# Altium schematic sheet 08 CAN .SchDoc
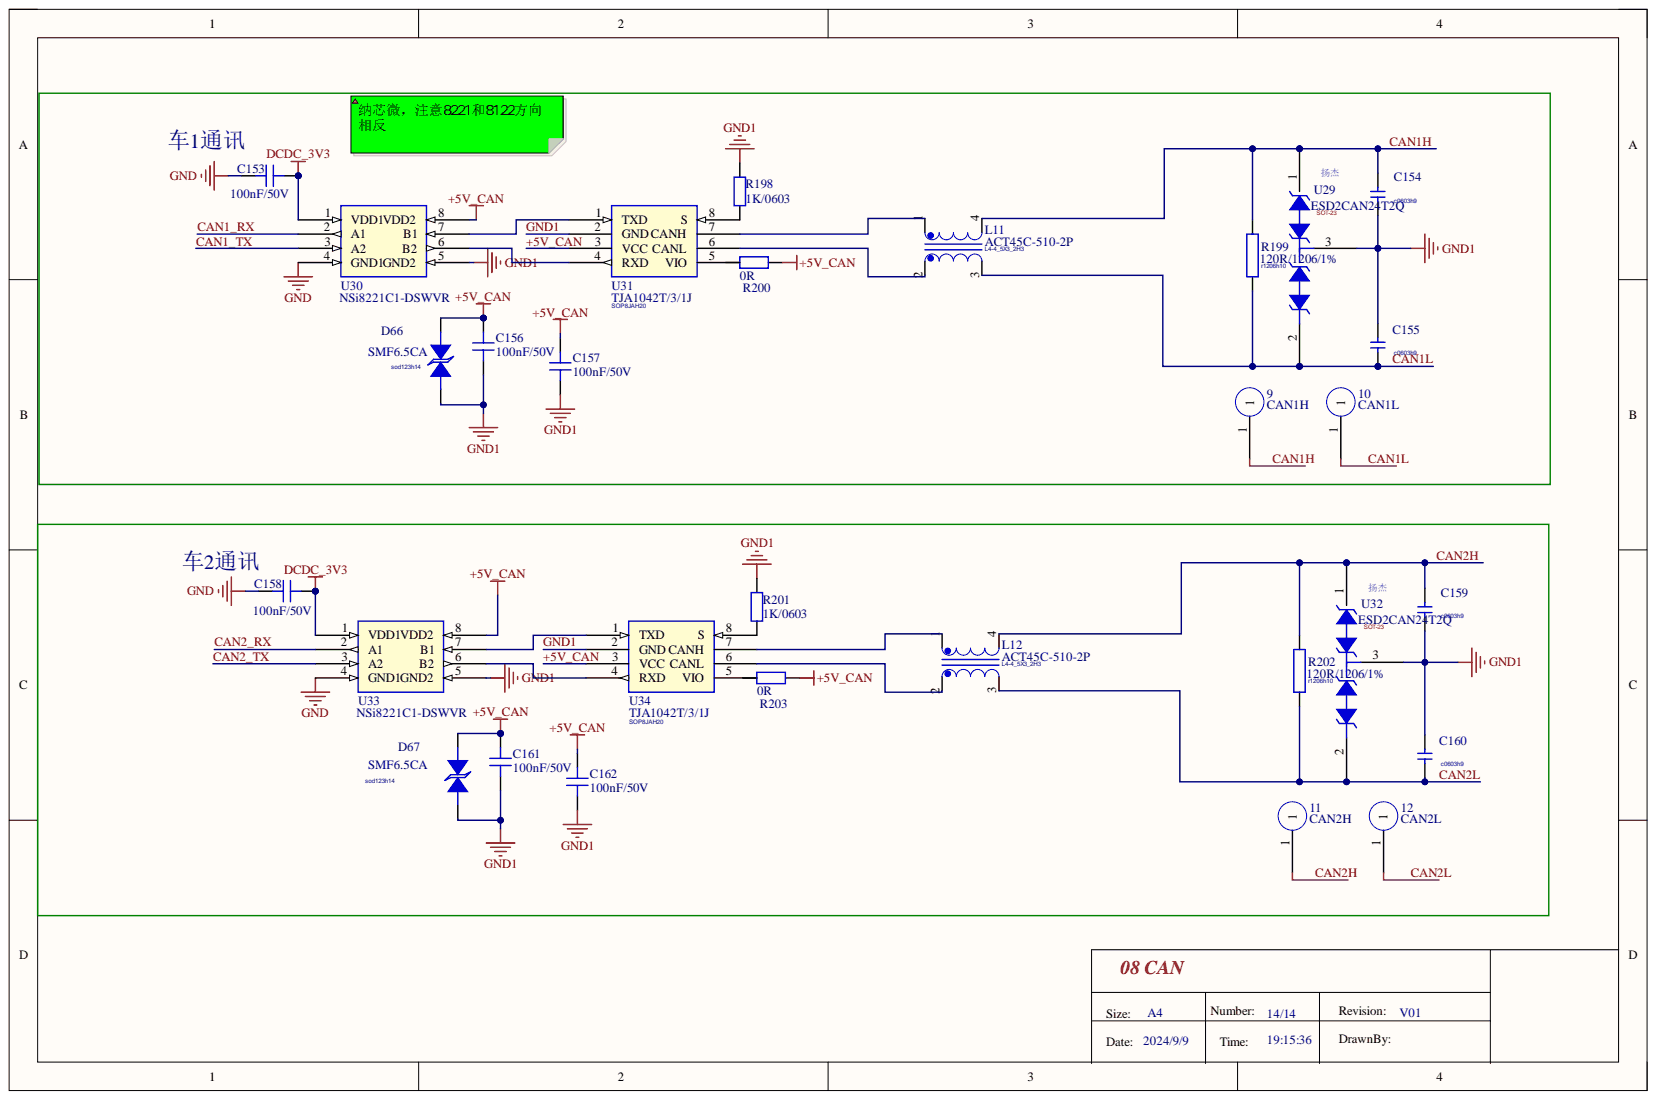
[diagram p0: 08 CAN .SchDoc]

## Parts
9  CAN1H  [TP12]
10  CAN1L  [TP12]
11  CAN2H  [TP12]
12  CAN2L  [TP12]
C153  100nF/50V  [C0603]
C154  PCB Footprint: c0603h9  [C0603]
C155  PCB Footprint: c0603h9  [C0603]
C156  100nF/50V  [C0603]
C157  100nF/50V  [C0603]
C158  100nF/50V  [C0603]
C159  PCB Footprint: c0603h9  [C0603]
C160  PCB Footprint: c0603h9  [C0603]
C161  100nF/50V  [C0603]
C162  100nF/50V  [C0603]
D66  SMF6.5CA  [sod123h14]
D67  SMF6.5CA  [sod123h14]
L11  ACT45C-510-2P  [L4-4_5X3_2H3]
L12  ACT45C-510-2P  [L4-4_5X3_2H3]
R198  1K/0603  [R1206]
R199  120R/1206/1%  [r1206h10]
R200  0R  [R1206]
R201  1K/0603  [R1206]
R202  120R/1206/1%  [r1206h10]
R203  0R  [R1206]
U29  ESD2CAN24T2Q  [SOT-23]
U30  NSi8221C1-DSWVR  [SOIC-8_300mil]
U31  TJA1042T/3/1J  [SOIC-8_150mil]
U32  ESD2CAN24T2Q  [SOT-23]
U33  NSi8221C1-DSWVR  [SOIC-8_300mil]
U34  TJA1042T/3/1J  [SOIC-8_150mil]

## Nets
DCDC_3V3: C153.2 C158.2 U30.1(VDD1) U33.1(VDD1)
GND: C153.1 C158.1 U30.4(GND1) U33.4(GND1)
+5V_CAN: C156.1 C157.1 C161.1 C162.1 D66.2 D67.2 R200.1 R203.1 U30.8(VDD2) U31.3(VCC) U33.8(VDD2) U34.3(VCC)
CAN1_RX: U30.2(A1)
CAN1_TX: U30.3(A2)
CAN1H: 9.1 C154.2 L11.4 R199.1 U29.1
CAN1L: 10.1 C155.1 L11.3 R199.2 U29.2
CAN2_RX: U33.2(A1)
CAN2_TX: U33.3(A2)
CAN2H: 11.1 C159.2 L12.4 R202.1 U32.1
CAN2L: 12.1 C160.1 L12.3 R202.2 U32.2
GND1: C154.1 C155.2 C156.2 C157.2 C159.1 C160.2 C161.2 C162.2 D66.1 D67.1 R198.2 R201.2 U29.3 U30.5(GND2) U31.2(GND) U32.3 U33.5(GND2) U34.2(GND)
NetL11_1: L11.1 U31.7(CANH)
NetL11_2: L11.2 U31.6(CANL)
NetL12_1: L12.1 U34.7(CANH)
NetL12_2: L12.2 U34.6(CANL)
NetR198_1: R198.1 U31.8(S)
NetR201_1: R201.1 U34.8(S)
NetU30_6: U30.6(B2) U31.4(RXD)
NetU30_7: U30.7(B1) U31.1(TXD)
NetU33_6: U33.6(B2) U34.4(RXD)
NetU33_7: U33.7(B1) U34.1(TXD)

Not connected: R200 2; R203 2; U31 5(VIO); U34 5(VIO)

## Library data of the parts
9-12 = PAD: Component Kind: Standard; PCB Footprint: TP12
C153 C158 = 100nF_50V_10%: 片状电容器－50V－100nF±10%－X7R; MPN: C2012X7R1H104KT; Part T: 贴片电容; ERP code: E01030007; MN: TDK; Component Kind: Standard; Library Name: Component Library.IntLib
C154 C155 C159 C160 = c_1: PSpiceTemplate: C^@REFDES %1 %2 ?TOLERANCE|C^@REFDES| @VALUE ?IC/IC=@IC/ ?TC/TC=@TC/ ?TOLERANCE|\n.model C^@REFDES CAP C=1 DEV=@TOLERANCE%|; Comment: =Value; Component Kind: Standard; Simulation: c_1; Value: =Value
C156 C157 C161 C162 = 100nF_50V_10%: 片状电容器－50V－100nF±10%－X7R－0805; MPN: C2012X7R1H104KT; Part T: 贴片电容; ERP code: E01030007; MN: TDK; Component Kind: Standard; Library Name: Component Library.IntLib
D66 D67 = DIODE TVS BI-DIR_1: Component Kind: Standard; PCB Footprint: sod123h14
L11 L12 = TRNSFMR TY-402P_5: Component Kind: Standard; PCB Footprint: L4-4_5X3_2H3
R198 R200 R201 R203 = 510R_1/8W _: 片状电阻器－1/4W－120Ω/1%; MPN: RC0805FR-07510RL; ERP code: E00000042; Component Kind: Standard; Library Name: Component Library.IntLib; Signal Integrity: Res; Simulation: RESISTOR
  R198 R201: Value: 1K/0603
  R200 R203: Value: 0R
R199 R202 = R_2: Component Kind: Standard; PCB Footprint: r1206h10
U29 U32 = DIODE ZENER_11: Component Kind: Standard; PCB Footprint: SOT-23
U30 U33 = TJA1040T_10: PCB Footprint: SOW8; Component Kind: Standard
U31 U34 = TJA1040T_1: PCB Footprint: SOP8JAH20; Component Kind: Standard
